# Altium schematic sheet Jumper.SchDoc(Jumper)
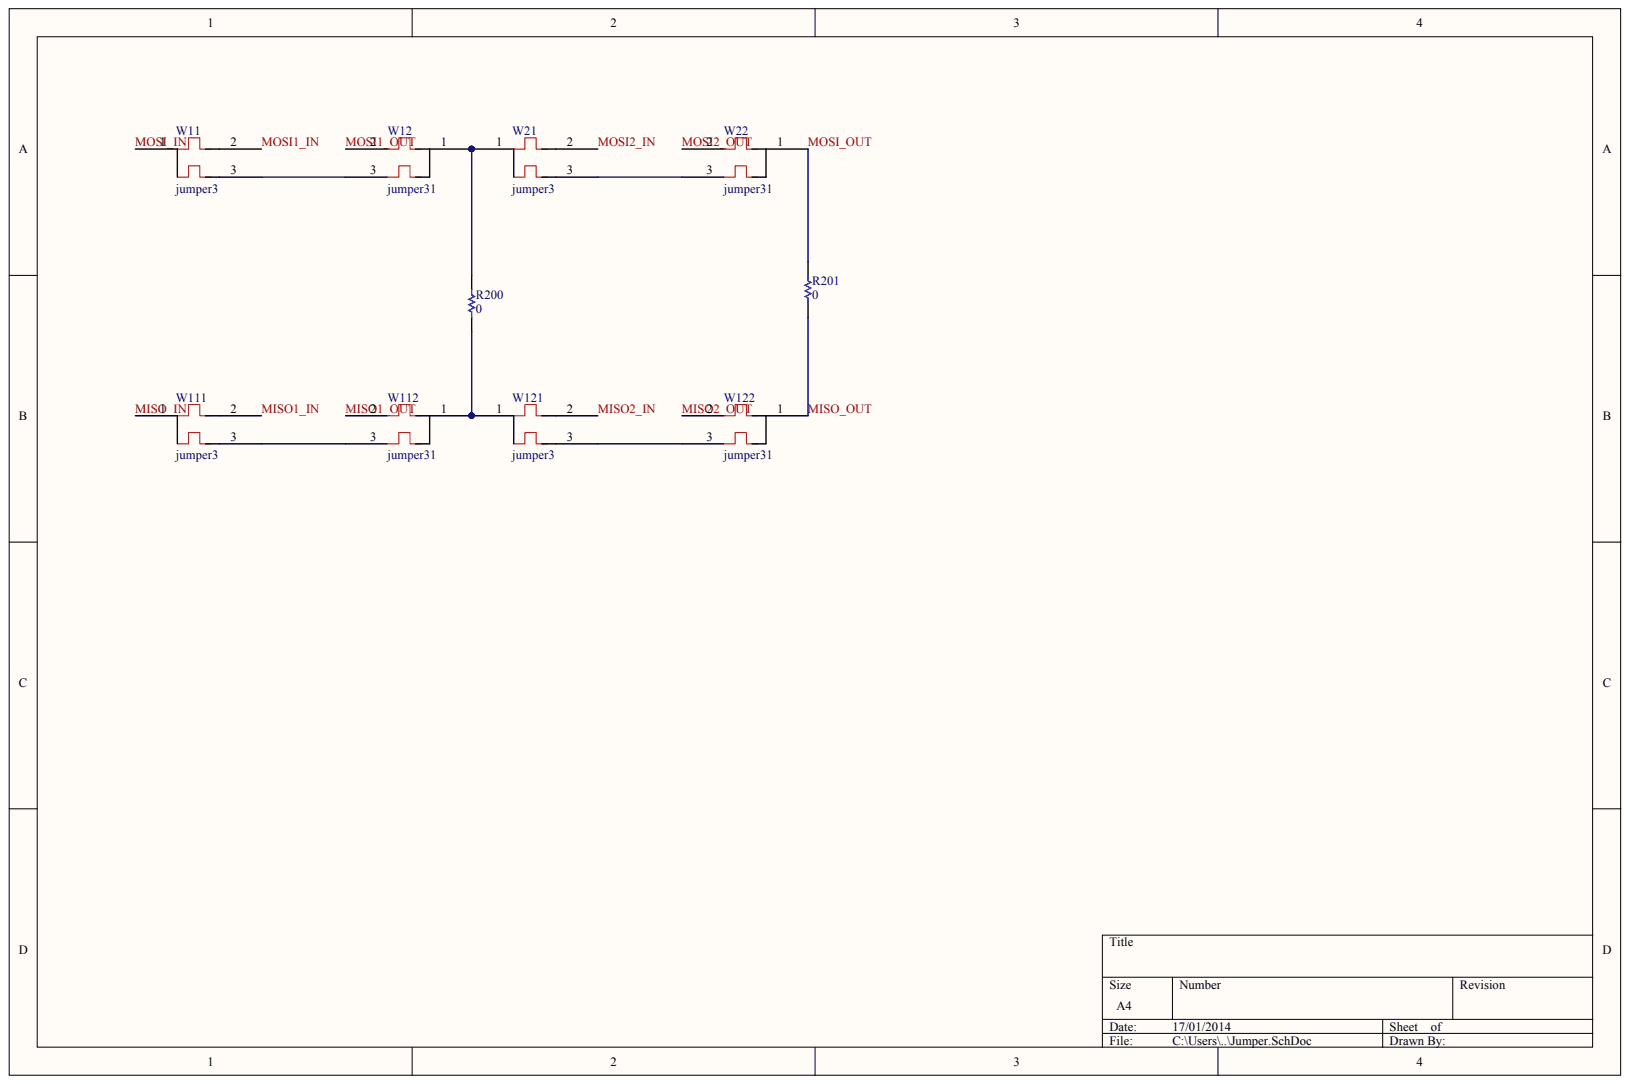
[diagram p0: Jumper.SchDoc]

## Parts
R200  0
R201  0
W11  jumper3
W12  jumper31
W21  jumper3
W22  jumper31
W111  jumper3
W112  jumper31
W121  jumper3
W122  jumper31

## Nets
MISO1_IN: W111.2
MISO1_OUT: W112.2
MISO2_IN: W121.2
MISO2_OUT: W122.2
MISO_IN: W111.1
MISO_OUT: R201.1 W122.1
MOSI1_IN: W11.2
MOSI1_OUT: W12.2
MOSI2_IN: W21.2
MOSI2_OUT: W22.2
MOSI_IN: W11.1
MOSI_OUT: R201.2 W22.1
NetR200_1: R200.1 W112.1 W121.1
NetR200_2: R200.2 W12.1 W21.1
NetW11_3: W11.3 W12.3
NetW21_3: W21.3 W22.3
NetW111_3: W111.3 W112.3
NetW121_3: W121.3 W122.3

## Library data of the parts
R200 R201 W11 W12 W21 W22 W111 W112 W121 W122 = (no symbol name)
  R200 R201: Published: 8-Jun-2000; LatestRevisionDate: 17-Jul-2002; LatestRevisionNote: Re-released for DXP Platform.; PackageReference: AXIAL-0.3; PackageDescription: Axial Device, Thru-Hole; 2 Leads; 0.3 in Pin Spacing; Value: 0; Publisher: Altium Limited
